# Altium schematic sheet accessPoint_MCU2.SchDoc(accessPoint_MCU2)
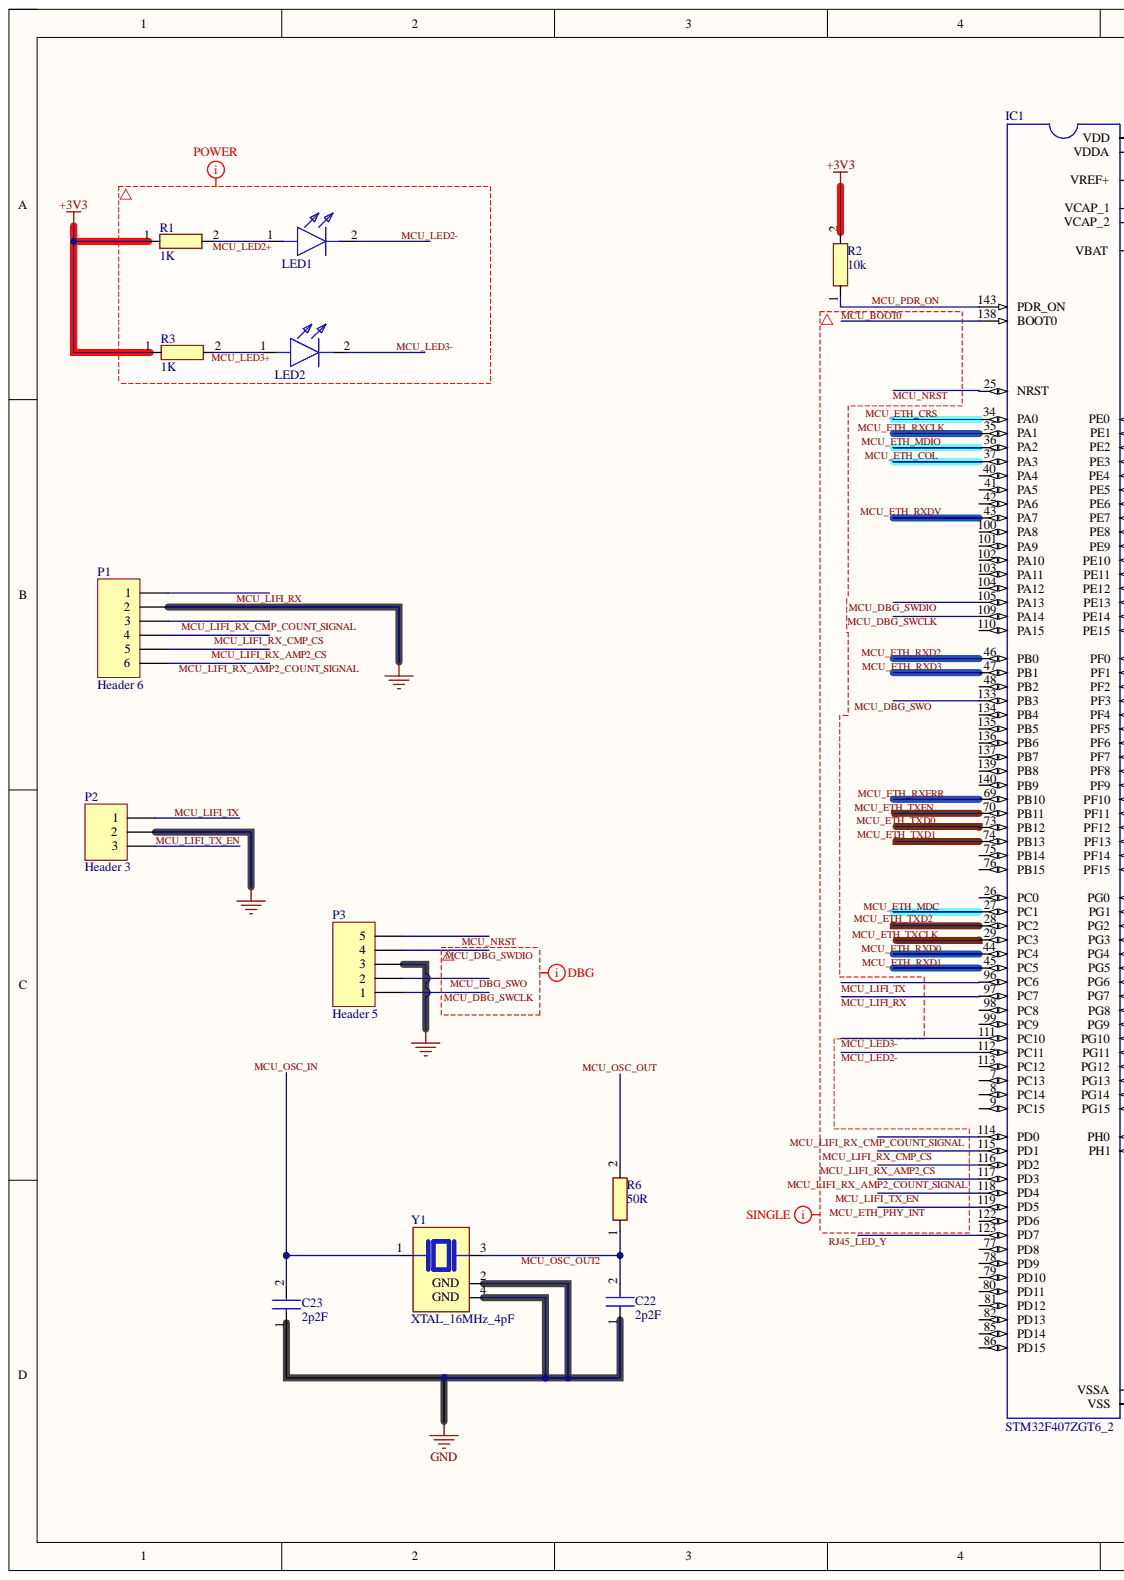
[diagram p0: accessPoint_MCU2.SchDoc, left]
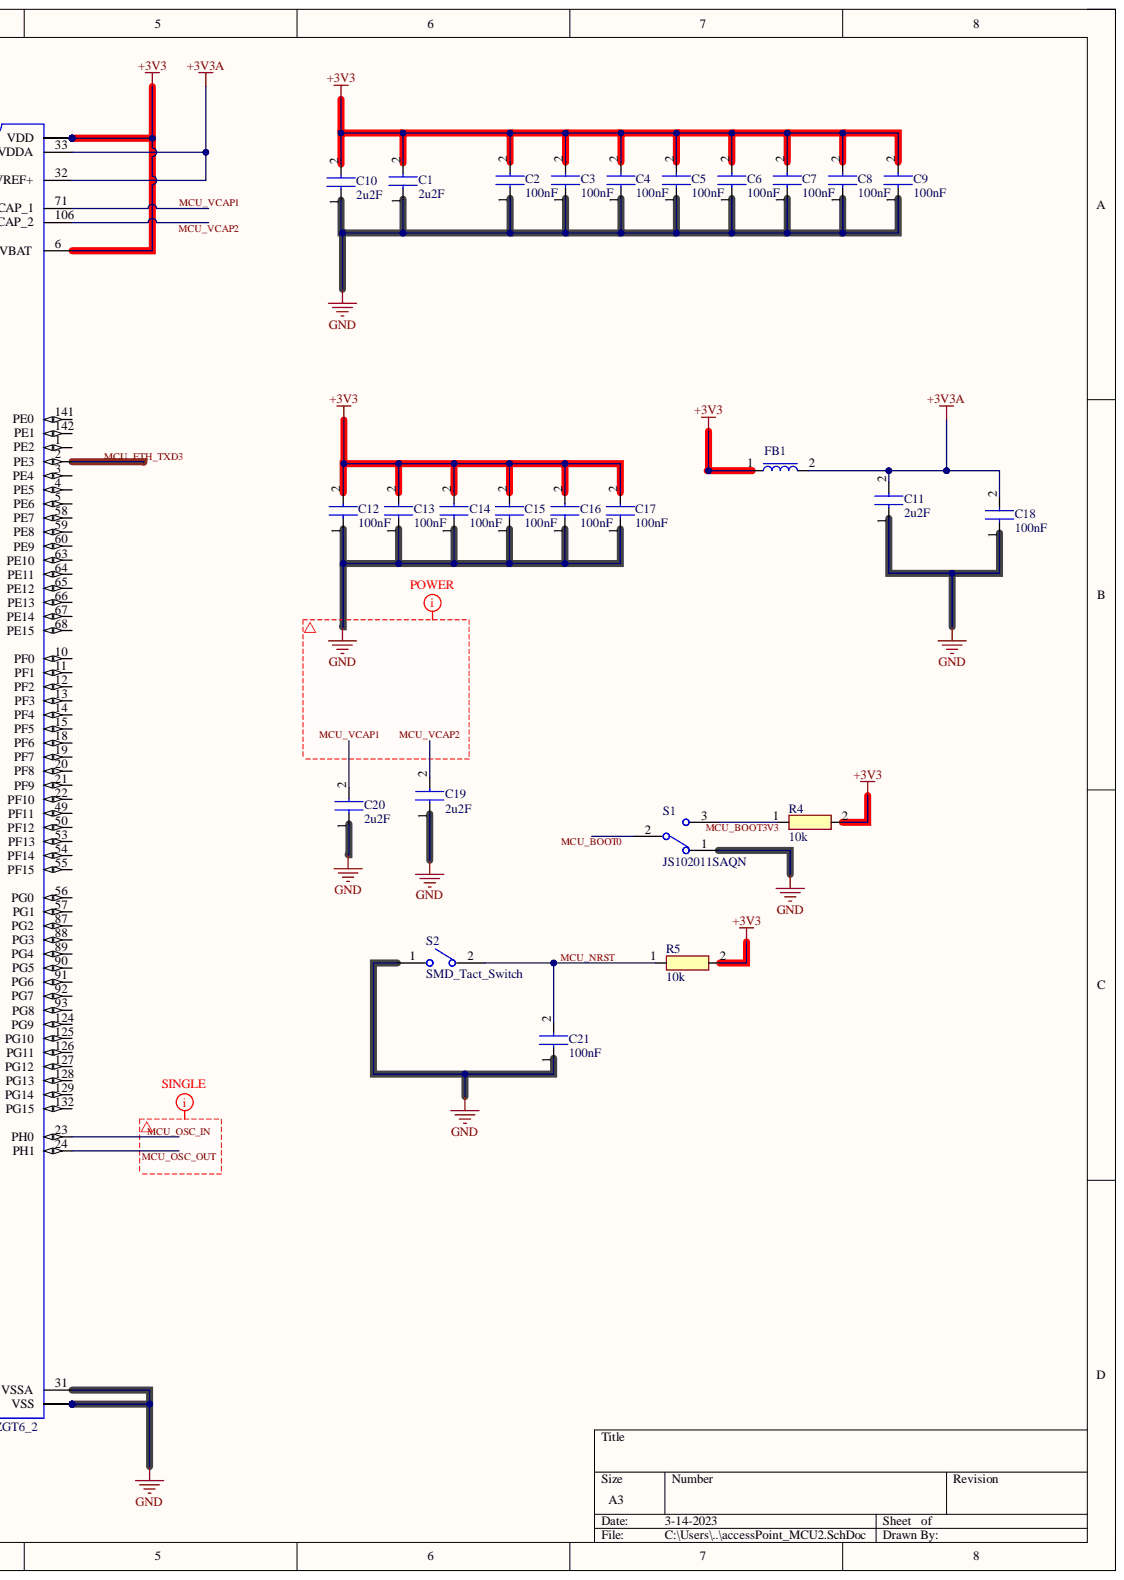
[diagram p1: accessPoint_MCU2.SchDoc, right]

## Parts
C1  2u2F  [0603]
C2  100nF  [0603]
C3  100nF  [0603]
C4  100nF  [0603]
C5  100nF  [0603]
C6  100nF  [0603]
C7  100nF  [0603]
C8  100nF  [0603]
C9  100nF  [0603]
C10  2u2F  [0603]
C11  2u2F  [0603]
C12  100nF  [0603]
C13  100nF  [0603]
C14  100nF  [0603]
C15  100nF  [0603]
C16  100nF  [0603]
C17  100nF  [0603]
C18  100nF  [0603]
C19  2u2F  [0603]
C20  2u2F  [0603]
C21  100nF  [0603]
C22  2p2F  [0603]
C23  2p2F  [0603]
FB1  Ferrite Bead @100R  [BEADC1608X90N]  (value not drawn)
IC1  STM32F407ZGT6_2  [MCU_STM32F407ZGT6]
LED1  0603 LED Green  [0603 LED]  (value not drawn)
LED2  0603 LED Green  [0603 LED]  (value not drawn)
P1  Header 6  [HDR1X6]
P2  Header 3  [HDR1X3]
P3  Header 5  [HDR1X5]
R1  1K  [0603]
R2  10k  [0603]
R3  1K  [0603]
R4  10k  [0603]
R5  10k  [0603]
R6  50R  [0603]
S1  JS102011SAQN  [SW_JS102011SAQN]
S2  SMD_Tact_Switch  [SMD_Tact_Switch]
Y1  XTAL_16MHz_4pF  [XTAL_16.0000MHZ_4PF]

## Nets
+3V3: C1.2 C2.2 C3.2 C4.2 C5.2 C6.2 C7.2 C8.2 C9.2 C10.2 C12.2 C13.2 C14.2 C15.2 C16.2 C17.2 FB1.1 IC1.6(VBAT) IC1.17(VDD) IC1.30 IC1.39 IC1.52 IC1.62 IC1.72 IC1.84 IC1.95 IC1.108 IC1.121 IC1.131 IC1.144 R1.1 R2.2 R3.1 R4.2 R5.2
+3V3A: C11.2 C18.2 FB1.2 IC1.32(VREF+) IC1.33(VDDA)
GND: C1.1 C2.1 C3.1 C4.1 C5.1 C6.1 C7.1 C8.1 C9.1 C10.1 C11.1 C12.1 C13.1 C14.1 C15.1 C16.1 C17.1 C18.1 C19.1 C20.1 C21.1 C22.1 C23.1 IC1.16(VSS) IC1.31(VSSA) IC1.38 IC1.51 IC1.61 IC1.83 IC1.94 IC1.107 IC1.120 IC1.130 P1.2 P2.2 P3.3 S1.1 S2.1 Y1.2(GND) Y1.4(GND)
MCU_BOOT0: IC1.138(BOOT0) S1.2
MCU_BOOT3V3: R4.1 S1.3
MCU_DBG_SWCLK: IC1.109(PA14) P3.1
MCU_DBG_SWDIO: IC1.105(PA13) P3.4
MCU_DBG_SWO: IC1.133(PB3) P3.2
MCU_ETH_COL: IC1.37(PA3)
MCU_ETH_CRS: IC1.34(PA0)
MCU_ETH_MDC: IC1.27(PC1)
MCU_ETH_MDIO: IC1.36(PA2)
MCU_ETH_PHY_INT: IC1.119(PD5)
MCU_ETH_RXCLK: IC1.35(PA1)
MCU_ETH_RXD0: IC1.44(PC4)
MCU_ETH_RXD1: IC1.45(PC5)
MCU_ETH_RXD2: IC1.46(PB0)
MCU_ETH_RXD3: IC1.47(PB1)
MCU_ETH_RXDV: IC1.43(PA7)
MCU_ETH_RXERR: IC1.69(PB10)
MCU_ETH_TXCLK: IC1.29(PC3)
MCU_ETH_TXD0: IC1.73(PB12)
MCU_ETH_TXD1: IC1.74(PB13)
MCU_ETH_TXD2: IC1.28(PC2)
MCU_ETH_TXD3: IC1.2(PE3)
MCU_ETH_TXEN: IC1.70(PB11)
MCU_LED2+: LED1.1 R1.2
MCU_LED2-: IC1.112(PC11) LED1.2
MCU_LED3+: LED2.1 R3.2
MCU_LED3-: IC1.111(PC10) LED2.2
MCU_LIFI_RX: IC1.97(PC7) P1.1
MCU_LIFI_RX_AMP2_COUNT_SIGNAL: IC1.117(PD3) P1.6
MCU_LIFI_RX_AMP2_CS: IC1.116(PD2) P1.5
MCU_LIFI_RX_CMP_COUNT_SIGNAL: IC1.114(PD0) P1.3
MCU_LIFI_RX_CMP_CS: IC1.115(PD1) P1.4
MCU_LIFI_TX: IC1.96(PC6) P2.1
MCU_LIFI_TX_EN: IC1.118(PD4) P2.3
MCU_NRST: C21.2 IC1.25(NRST) P3.5 R5.1 S2.2
MCU_OSC_IN: C23.2 IC1.23(PH0) Y1.1
MCU_OSC_OUT: IC1.24(PH1) R6.2
MCU_OSC_OUT2: C22.2 R6.1 Y1.3
MCU_PDR_ON: IC1.143(PDR_ON) R2.1
MCU_VCAP1: C20.2 IC1.71(VCAP_1)
MCU_VCAP2: C19.2 IC1.106(VCAP_2)
RJ45_LED_Y: IC1.123(PD7)

Not connected: IC1 1(PE2) 3(PE4) 4(PE5) 5(PE6) 7(PC13) 8(PC14) 9(PC15) 10(PF0) 11(PF1) 12(PF2) 13(PF3) 14(PF4) 15(PF5) 18(PF6) 19(PF7) 20(PF8) 21(PF9) 22(PF10) 26(PC0) 40(PA4) 41(PA5) 42(PA6) 48(PB2) 49(PF11) 50(PF12) 53(PF13) 54(PF14) 55(PF15) 56(PG0) 57(PG1) 58(PE7) 59(PE8) 60(PE9) 63(PE10) 64(PE11) 65(PE12) 66(PE13) 67(PE14) 68(PE15) 75(PB14) 76(PB15) 77(PD8) 78(PD9) 79(PD10) 80(PD11) 81(PD12) 82(PD13) 85(PD14) 86(PD15) 87(PG2) 88(PG3) 89(PG4) 90(PG5) 91(PG6) 92(PG7) 93(PG8) 98(PC8) 99(PC9) 100(PA8) 101(PA9) 102(PA10) 103(PA11) 104(PA12) 110(PA15) 113(PC12) 122(PD6) 124(PG9) 125(PG10) 126(PG11) 127(PG12) 128(PG13) 129(PG14) 132(PG15) 134(PB4) 135(PB5) 136(PB6) 137(PB7) 139(PB8) 140(PB9) 141(PE0) 142(PE1)

## Library data of the parts
C1-C23 = 0603C: Component Kind: Standard; Library Name: accessPoint.SchLib
FB1 = Ferrite Bead @100R: Ferrite Bead; Manufacturer_Name: KEMET; Manufacturer_Part_Number: Z0603C101BPWZT; Arrow Part Number: Z0603C101BPWZT; Arrow Price/Stock: https://www.arrow.com/en/products/z0603c101bpwzt/kemet-corporation; Component Kind: Standard; Datasheet Link: https://api.kemet.com/component-edge/download/datasheet/Z0603C101BPWZT.pdf; Height: 0.9mm; Mouser Part Number: 80-Z0603C101BPWZT; Mouser Price/Stock: https://www.mouser.co.uk/ProductDetail/KEMET/Z0603C101BPWZT?qs=BYlwlsDsB%2FqttfRwYpxQxg%3D%3D; Library Name: accessPoint.SchLib
IC1 = STM32F407ZGT6_2: MCU 32-bit STM32F ARM Cortex M4F RISC 1024KB Flash 2.5V/3.3V 144-Pin LQFP Tray; MF: {'manufacturer': u'STMicroelectronics'}; Availability: Unavailable; Component Kind: Standard; MP: STM32F407ZGT6; Package: None; Price: None; Library Name: accessPoint.SchLib
LED1 LED2 = 0603 LED Green: LED; Manufacturer_Name: Wurth Elektronik; Manufacturer_Part_Number: 150060VS75003; Component Kind: Standard; Datasheet Link: https://www.we-online.com/catalog/datasheet/150060VS75003.pdf; Height: 0.8mm; Mouser Part Number: 710-150060VS75003; Mouser Price/Stock: https://www.mouser.co.uk/ProductDetail/Wurth-Elektronik/150060VS75003?qs=Li%252BoUPsLEnv2ZkbQUMi3uQ%3D%3D; Library Name: accessPoint.SchLib
P1 = Header 6: Header, 6-Pin; Component Kind: Standard; LatestRevisionDate: 17-Jul-2002; LatestRevisionNote: Re-released for DXP Platform.; Library Name: Miscellaneous Connectors.IntLib; Signal Integrity: Connector; Publisher: Altium Limited
P2 = Header 3: Header, 3-Pin; Component Kind: Standard; LatestRevisionDate: 17-Jul-2002; LatestRevisionNote: Re-released for DXP Platform.; Library Name: Miscellaneous Connectors.IntLib; Signal Integrity: Connector; Publisher: Altium Limited
P3 = Header 5: Header, 5-Pin; Component Kind: Standard; LatestRevisionDate: 17-Jul-2002; LatestRevisionNote: Re-released for DXP Platform.; Library Name: Miscellaneous Connectors.IntLib; Signal Integrity: Connector; Publisher: Altium Limited
R1-R6 = 0603R: Component Kind: Standard; Library Name: accessPoint.SchLib
S1 = JS102011SAQN: Slide Switch SPDT Surface Mount, Right Angle; MF: C&K; Availability: Unavailable; Component Kind: Standard; MP: JS102011SAQN; Package: None; Price: None; SnapEDA_Link: https://www.snapeda.com/parts/JS102011SAQN/C%26K/view-part/214325/?ref=snap; Library Name: accessPoint.SchLib
S2 = SMD_Tact_Switch: SMD Tactile Switch, 3x6x2.5mm; Component Kind: Standard; Library Name: accessPoint.SchLib
Y1 = XTAL_16MHz_4pF: ABM10W-16.0000MHZ-4-D1X-T3; MF: {'manufacturer': u'Abracon LLC'}; Availability: Unavailable; Component Kind: Standard; MP: ABM10W-16.0000MHZ-4-D1X-T3; Package: None; Price: None; Library Name: accessPoint.SchLib
